# Altium schematic sheet FPGA PL.SchDoc("FPGA PL")
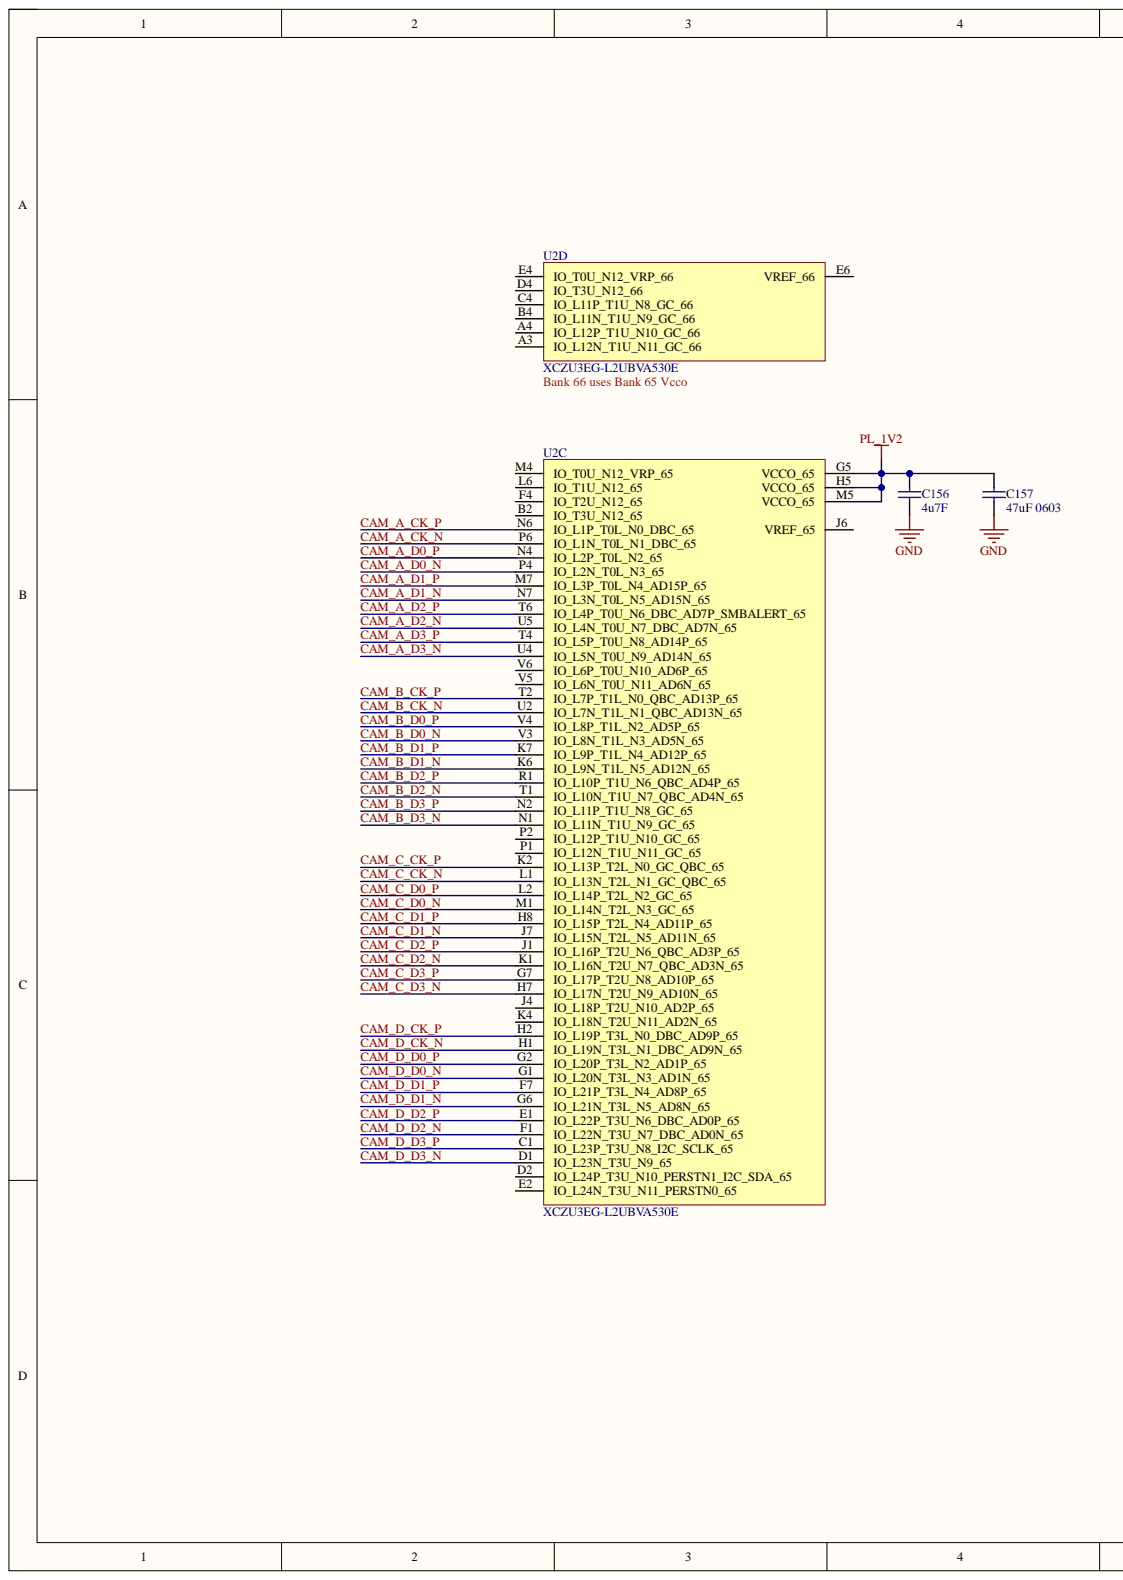
[diagram p0: FPGA PL.SchDoc, left]
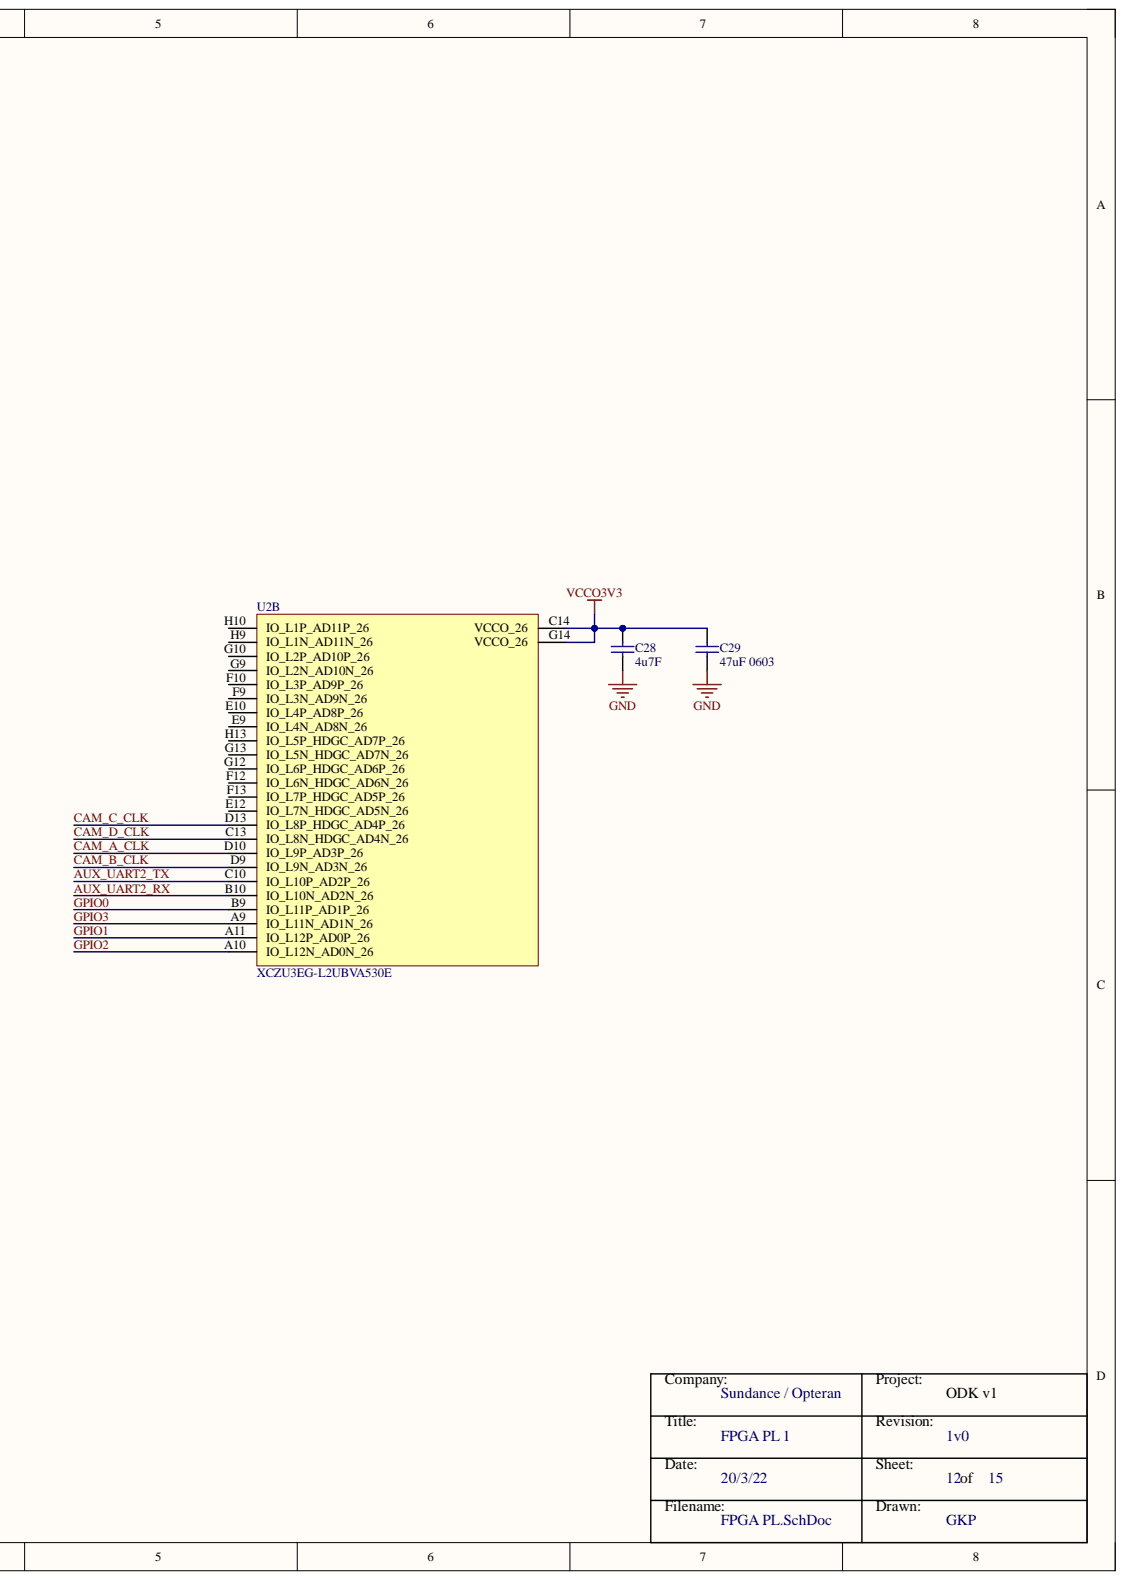
[diagram p1: FPGA PL.SchDoc, right]

## Parts
C28  4u7F  [0201panERJ]
C29  47uF 0603  Case-EIA: 0603  [CAP0603]
C156  4u7F  [0201panERJ]
C157  47uF 0603  Case-EIA: 0603  [CAP0603]
U2  XCZU3EG-L2UBVA530E  [UBVA530]  (parts B,C,D)

## Nets
GND: C28.1 C29.1 C156.1 C157.1
PL_1V2: C156.2 C157.2 U2.G5(VCCO_65) U2.H5(VCCO_65) U2.M5(VCCO_65)
VCCO3V3: C28.2 C29.2 U2.C14(VCCO_26) U2.G14(VCCO_26)
AUX_UART2_RX: U2.B10(IO_L10N_AD2N_26)
AUX_UART2_TX: U2.C10(IO_L10P_AD2P_26)
CAM_A_CK_N: U2.P6(IO_L1N_T0L_N1_DBC_65)
CAM_A_CK_P: U2.N6(IO_L1P_T0L_N0_DBC_65)
CAM_A_CLK: U2.D10(IO_L9P_AD3P_26)
CAM_A_D0_N: U2.P4(IO_L2N_T0L_N3_65)
CAM_A_D0_P: U2.N4(IO_L2P_T0L_N2_65)
CAM_A_D1_N: U2.N7(IO_L3N_T0L_N5_AD15N_65)
CAM_A_D1_P: U2.M7(IO_L3P_T0L_N4_AD15P_65)
CAM_A_D2_N: U2.U5(IO_L4N_T0U_N7_DBC_AD7N_65)
CAM_A_D2_P: U2.T6(IO_L4P_T0U_N6_DBC_AD7P_SMBALERT_65)
CAM_A_D3_N: U2.U4(IO_L5N_T0U_N9_AD14N_65)
CAM_A_D3_P: U2.T4(IO_L5P_T0U_N8_AD14P_65)
CAM_B_CK_N: U2.U2(IO_L7N_T1L_N1_QBC_AD13N_65)
CAM_B_CK_P: U2.T2(IO_L7P_T1L_N0_QBC_AD13P_65)
CAM_B_CLK: U2.D9(IO_L9N_AD3N_26)
CAM_B_D0_N: U2.V3(IO_L8N_T1L_N3_AD5N_65)
CAM_B_D0_P: U2.V4(IO_L8P_T1L_N2_AD5P_65)
CAM_B_D1_N: U2.K6(IO_L9N_T1L_N5_AD12N_65)
CAM_B_D1_P: U2.K7(IO_L9P_T1L_N4_AD12P_65)
CAM_B_D2_N: U2.T1(IO_L10N_T1U_N7_QBC_AD4N_65)
CAM_B_D2_P: U2.R1(IO_L10P_T1U_N6_QBC_AD4P_65)
CAM_B_D3_N: U2.N1(IO_L11N_T1U_N9_GC_65)
CAM_B_D3_P: U2.N2(IO_L11P_T1U_N8_GC_65)
CAM_C_CK_N: U2.L1(IO_L13N_T2L_N1_GC_QBC_65)
CAM_C_CK_P: U2.K2(IO_L13P_T2L_N0_GC_QBC_65)
CAM_C_CLK: U2.D13(IO_L8P_HDGC_AD4P_26)
CAM_C_D0_N: U2.M1(IO_L14N_T2L_N3_GC_65)
CAM_C_D0_P: U2.L2(IO_L14P_T2L_N2_GC_65)
CAM_C_D1_N: U2.J7(IO_L15N_T2L_N5_AD11N_65)
CAM_C_D1_P: U2.H8(IO_L15P_T2L_N4_AD11P_65)
CAM_C_D2_N: U2.K1(IO_L16N_T2U_N7_QBC_AD3N_65)
CAM_C_D2_P: U2.J1(IO_L16P_T2U_N6_QBC_AD3P_65)
CAM_C_D3_N: U2.H7(IO_L17N_T2U_N9_AD10N_65)
CAM_C_D3_P: U2.G7(IO_L17P_T2U_N8_AD10P_65)
CAM_D_CK_N: U2.H1(IO_L19N_T3L_N1_DBC_AD9N_65)
CAM_D_CK_P: U2.H2(IO_L19P_T3L_N0_DBC_AD9P_65)
CAM_D_CLK: U2.C13(IO_L8N_HDGC_AD4N_26)
CAM_D_D0_N: U2.G1(IO_L20N_T3L_N3_AD1N_65)
CAM_D_D0_P: U2.G2(IO_L20P_T3L_N2_AD1P_65)
CAM_D_D1_N: U2.G6(IO_L21N_T3L_N5_AD8N_65)
CAM_D_D1_P: U2.F7(IO_L21P_T3L_N4_AD8P_65)
CAM_D_D2_N: U2.F1(IO_L22N_T3U_N7_DBC_AD0N_65)
CAM_D_D2_P: U2.E1(IO_L22P_T3U_N6_DBC_AD0P_65)
CAM_D_D3_N: U2.D1(IO_L23N_T3U_N9_65)
CAM_D_D3_P: U2.C1(IO_L23P_T3U_N8_I2C_SCLK_65)
GPIO0: U2.B9(IO_L11P_AD1P_26)
GPIO1: U2.A11(IO_L12P_AD0P_26)
GPIO2: U2.A10(IO_L12N_AD0N_26)
GPIO3: U2.A9(IO_L11N_AD1N_26)

Not connected: U2 A3(IO_L12N_T1U_N11_GC_66) A4(IO_L12P_T1U_N10_GC_66) B2(IO_T3U_N12_65) B4(IO_L11N_T1U_N9_GC_66) C4(IO_L11P_T1U_N8_GC_66) D2(IO_L24P_T3U_N10_PERSTN1_I2C_SDA_65) D4(IO_T3U_N12_66) E2(IO_L24N_T3U_N11_PERSTN0_65) E4(IO_T0U_N12_VRP_66) E6(VREF_66) E9(IO_L4N_AD8N_26) E10(IO_L4P_AD8P_26) E12(IO_L7N_HDGC_AD5N_26) F4(IO_T2U_N12_65) F9(IO_L3N_AD9N_26) F10(IO_L3P_AD9P_26) F12(IO_L6N_HDGC_AD6N_26) F13(IO_L7P_HDGC_AD5P_26) G9(IO_L2N_AD10N_26) G10(IO_L2P_AD10P_26) G12(IO_L6P_HDGC_AD6P_26) G13(IO_L5N_HDGC_AD7N_26) H9(IO_L1N_AD11N_26) H10(IO_L1P_AD11P_26) H13(IO_L5P_HDGC_AD7P_26) J4(IO_L18P_T2U_N10_AD2P_65) J6(VREF_65) K4(IO_L18N_T2U_N11_AD2N_65) L6(IO_T1U_N12_65) M4(IO_T0U_N12_VRP_65) P1(IO_L12N_T1U_N11_GC_65) P2(IO_L12P_T1U_N10_GC_65) V5(IO_L6N_T0U_N11_AD6N_65) V6(IO_L6P_T0U_N10_AD6P_65)

## Library data of the parts
C28 C156 = Cap0201: 4u7F 0201 6V3 X5R; Case-EIA: 0402; Component Kind: Standard; Library Name: Schematic Components.SchLib; Max Thickness: 0.6 mm; Technology: SMT
C29 C157 = Cap0603: 47uF 6V3 0603 X5R; Component Kind: Standard; Library Name: Schematic Components.SchLib; Max Thickness: 0.6 mm; Technology: SMT
U2 = XCZU3EG-L2UBVA530E: SoC 32-bit/64-bit ARM Cortex-A53 RISC 1.5GHz Quad Core 530-Pin BGA; Manufacturer 1: Xilinx; Component Kind: Standard; Library Name: Xilinx1.SchLib; Manufacturer Part Number 1: XCZU3EG-L2UBVA530E; Supplier 1: Avnet; Supplier Part Number 1: XCZU3EG-L2UBVA530E
